# Altium schematic sheet FC11.SchDoc(FC11)
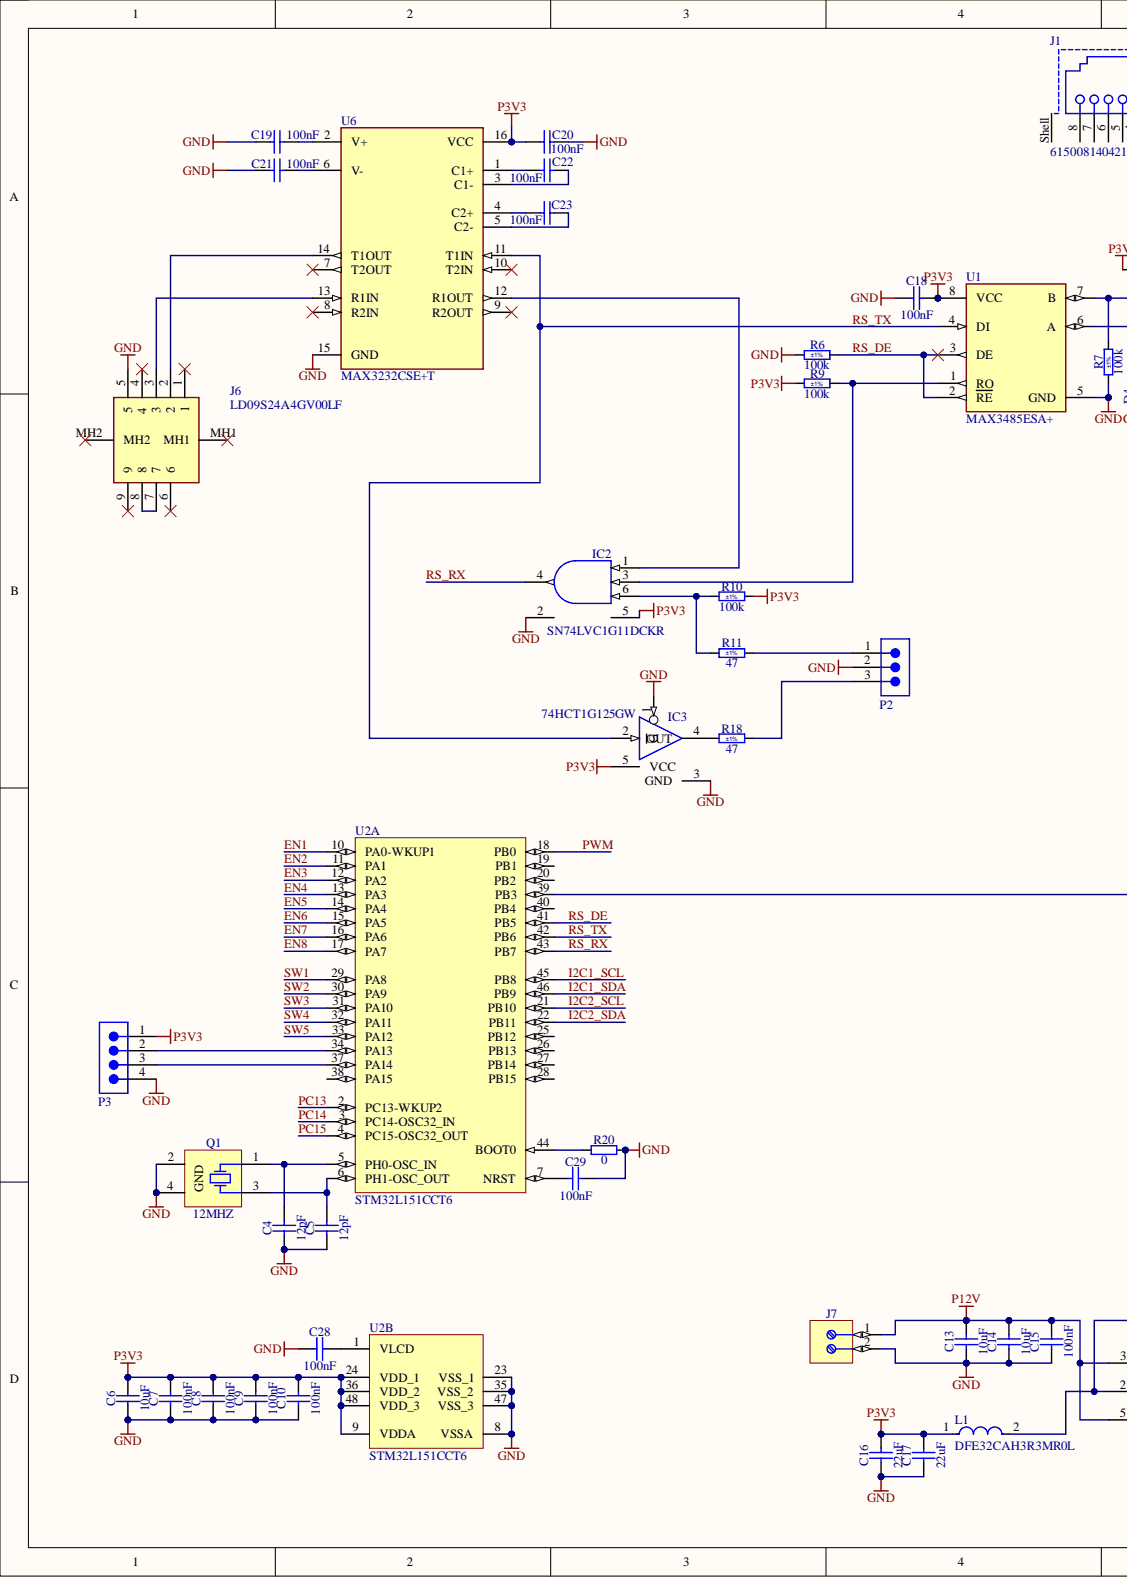
[diagram p0: FC11.SchDoc, left]
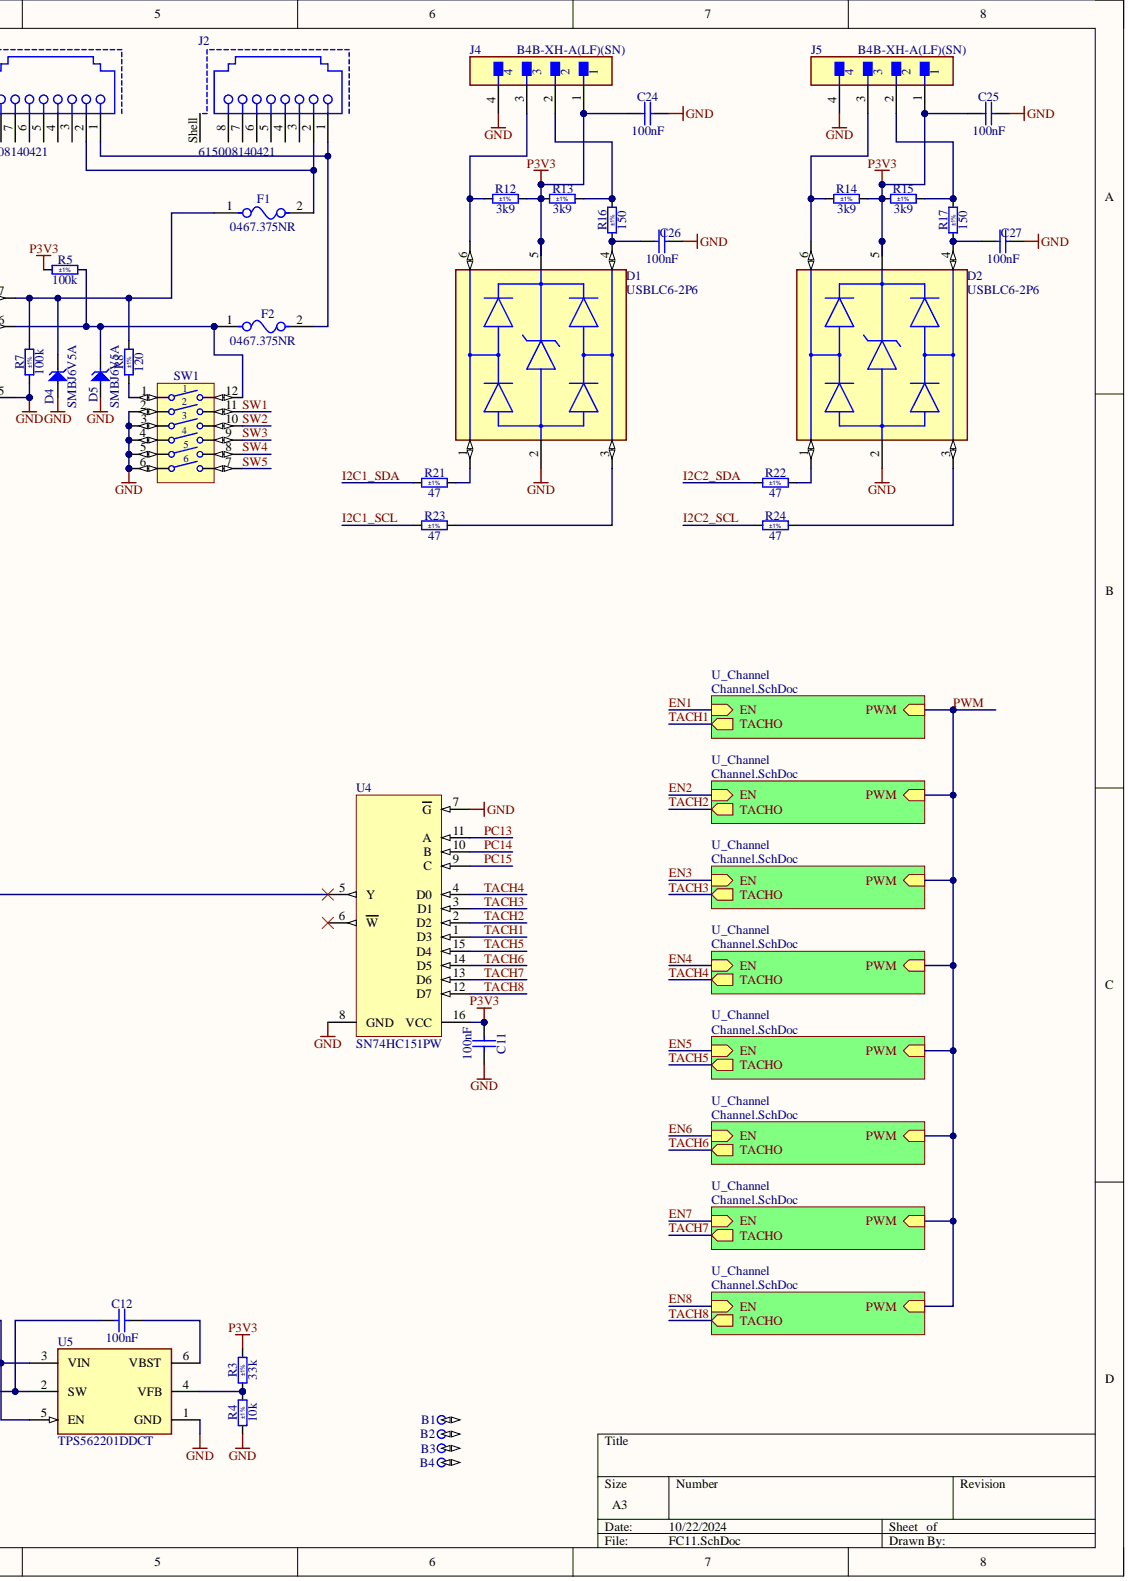
[diagram p1: FC11.SchDoc, right]

## Parts
B1  Plated Hole  [MTG300_600]  (value not drawn)  (no BOM)
B2  Plated Hole  [MTG300_600]  (value not drawn)  (no BOM)
B3  Plated Hole  [MTG300_600]  (value not drawn)  (no BOM)
B4  Plated Hole  [MTG300_600]  (value not drawn)  (no BOM)
C4  12pF  [CAPC1005X55N]
C5  12pF  [CAPC1005X55N]
C6  10uF  [CAPC2012X95N]
C7  100nF  [CAPC1005X55N]
C8  100nF  [CAPC1005X55N]
C9  100nF  [CAPC1005X55N]
C10  100nF  [CAPC1005X55N]
C11  100nF  [CAPC1005X55N]
C12  100nF  [CAPC1005X55N]
C13  10uF  [CAPC2012X95N]
C14  10uF  [CAPC2012X95N]
C15  100nF  [CAPC1005X55N]
C16  22uF  [CAPC2012X135N]
C17  22uF  [CAPC2012X135N]
C18  100nF  [CAPC1005X55N]
C19  100nF  [CAPC1005X55N]
C20  100nF  [CAPC1005X55N]
C21  100nF  [CAPC1005X55N]
C22  100nF  [CAPC1005X55N]
C23  100nF  [CAPC1005X55N]
C24  100nF  [CAPC1005X55N]
C25  100nF  [CAPC1005X55N]
C26  100nF  [CAPC1005X55N]
C27  100nF  [CAPC1005X55N]
C28  100nF  [CAPC1005X55N]
C29  100nF  [CAPC1005X55N]
D1  USBLC6-2P6
D2  USBLC6-2P6
D4  SMBJ6V5A  [DIOM5336X265N]
D5  SMBJ6V5A  [DIOM5336X265N]
F1  0467.375NR
F2  0467.375NR
IC2  SN74LVC1G11DCKR  [SOT65P210X110-6N]
IC3  74HCT1G125GW  [SOT65P210X110-5N]
J1  615008140421
J2  615008140421
J4  B4B-XH-A(LF)(SN)
J5  B4B-XH-A(LF)(SN)
J6  LD09S24A4GV00LF
J7  (no value)  [PHOENIX_1725656]
L1  DFE32CAH3R3MR0L
P2  61300311121  (value not drawn)
P3  61300411121  (value not drawn)
Q1  12MHZ  [XTAL_ABRACON_ABM3B]
R3  33k  Tolerance: ±1%  [RESC1005X40N]
R4  10k  Tolerance: ±1%  [RESC1005X40N]
R5  100k  Tolerance: ±1%  [RESC1005X40N]
R6  100k  Tolerance: ±1%  [RESC1005X40N]
R7  100k  Tolerance: ±1%  [RESC1005X40N]
R8  120  Tolerance: ±1%  [RESC1608X55N]
R9  100k  Tolerance: ±1%  [RESC1005X40N]
R10  100k  Tolerance: ±1%  [RESC1005X40N]
R11  47  Tolerance: ±1%  [RESC1005X40N]
R12  3k9  Tolerance: ±1%  [RESC1005X40N]
R13  3k9  Tolerance: ±1%  [RESC1005X40N]
R14  3k9  Tolerance: ±1%  [RESC1005X40N]
R15  3k9  Tolerance: ±1%  [RESC1005X40N]
R16  150  Tolerance: ±1%  [RESC1005X40N]
R17  150  Tolerance: ±1%  [RESC1005X40N]
R18  47  Tolerance: ±1%  [RESC1005X40N]
R20  0  [RESC1005X40N]
R21  47  Tolerance: ±1%  [RESC1005X40N]
R22  47  Tolerance: ±1%  [RESC1005X40N]
R23  47  Tolerance: ±1%  [RESC1005X40N]
R24  47  Tolerance: ±1%  [RESC1005X40N]
SW1  Switch'  [SW_OMRON_A6H-6102]  (value not drawn)
U1  MAX3485ESA+
U2  STM32L151CCT6  (parts A,B)
U4  SN74HC151PW
U5  TPS562201DDCT
U6  MAX3232CSE+T

## Nets
GND: C4.1 C5.1 C6.1 C7.1 C8.1 C9.1 C10.1 C11.1 C13.1 C14.1 C15.1 C16.1 C17.1 C18.1 C19.2 C20.1 C21.2 C24.2 C25.2 C26.2 C27.2 C28.1 C29.2 D1.2 D2.2 D4.2 D5.2 IC2.2 IC3.1(G) IC3.3(GND) J4.4 J5.4 J6.5 J7.2 P2.2 P3.4 Q1.2 Q1.4 R4.1 R6.1 R7.1 R20.2 SW1.2 SW1.3 SW1.4 SW1.5 SW1.6 U1.5(GND) U2.8(VSSA) U2.23(VSS_1) U2.35(VSS_2) U2.47(VSS_3) U4.7(G) U4.8(GND) U5.1(GND) U6.15(GND)
P3V3: C6.2 C7.2 C8.2 C9.2 C10.2 C11.2 C16.2 C17.2 C18.2 C20.2 C24.1 C25.1 D1.5 D2.5 IC2.5 IC3.5(VCC) J4.1 J5.1 L1.1 P3.1 R3.2 R5.1 R9.1 R10.2 R12.2 R13.1 R14.2 R15.1 U1.8(VCC) U2.9(VDDA) U2.24(VDD_1) U2.36(VDD_2) U2.48(VDD_3) U4.16(VCC) U6.16(VCC)
P12V: C13.2 C14.2 C15.2 J7.1 U5.3(VIN) U5.5(EN)
EN1: U2.10(PA0-WKUP1)
EN2: U2.11(PA1)
EN3: U2.12(PA2)
EN4: U2.13(PA3)
EN5: U2.14(PA4)
EN6: U2.15(PA5)
EN7: U2.16(PA6)
EN8: U2.17(PA7)
I2C1_SCL: R23.1 U2.45(PB8)
I2C1_SDA: R21.1 U2.46(PB9)
I2C2_SCL: R24.1 U2.21(PB10)
I2C2_SDA: R22.1 U2.22(PB11)
PC13: U2.2(PC13-WKUP2) U4.11(A)
PC14: U2.3(PC14-OSC32_IN) U4.10(B)
PC15: U2.4(PC15-OSC32_OUT) U4.9(C)
PWM: U2.18(PB0)
RS_DE: R6.2 U1.2(RE) U1.3(DE) U2.41(PB5)
RS_RX: IC2.4 U2.43(PB7)
RS_TX: IC3.2(OUT) U1.4(DI) U2.42(PB6) U6.11(T1IN)
SW1: SW1.11 U2.29(PA8)
SW2: SW1.10 U2.30(PA9)
SW3: SW1.9 U2.31(PA10)
SW4: SW1.8 U2.32(PA11)
SW5: SW1.7 U2.33(PA12)
TACH1: U4.1(D3)
TACH2: U4.2(D2)
TACH3: U4.3(D1)
TACH4: U4.4(D0)
TACH5: U4.15(D4)
TACH6: U4.14(D5)
TACH7: U4.13(D6)
TACH8: U4.12(D7)
NetB1_1: B1.1
NetB2_1: B2.1
NetB3_1: B3.1
NetB4_1: B4.1
NetC4_2: C4.2 Q1.1 U2.5(PH0-OSC_IN)
NetC5_2: C5.2 Q1.3 U2.6(PH1-OSC_OUT)
NetC12_1: C12.1 L1.2 U5.2(SW)
NetC12_2: C12.2 U5.6(VBST)
NetC19_1: C19.1 U6.2(V+)
NetC21_1: C21.1 U6.6(V-)
NetC22_1: C22.1 U6.3(C1-)
NetC22_2: C22.2 U6.1(C1+)
NetC23_1: C23.1 U6.5(C2-)
NetC23_2: C23.2 U6.4(C2+)
NetC26_1: C26.1 D1.4 R16.1
NetC27_1: C27.1 D2.4 R17.1
NetC28_2: C28.2 U2.1(VLCD)
NetC29_1: C29.1 U2.7(NRST)
NetD1_1: D1.1 R21.2
NetD1_3: D1.3 R23.2
NetD1_6: D1.6 J4.3 R12.1
NetD2_1: D2.1 R22.2
NetD2_3: D2.3 R24.2
NetD2_6: D2.6 J5.3 R14.1
NetD4_1: D4.1 F1.1 R7.2 R8.2 U1.7(B)
NetD5_1: D5.1 F2.1 R5.2 SW1.12 U1.6(A)
NetF1_2: F1.2 J1.2 J2.2
NetF2_2: F2.2 J1.1 J2.1
NetIC2_1: IC2.1 U6.12(R1OUT)
NetIC2_3: IC2.3 R9.2 U1.1(RO)
NetIC2_6: IC2.6 R10.1 R11.1
NetIC3_4: IC3.4 R18.1
NetJ1_3: J1.3
NetJ1_4: J1.4
NetJ1_5: J1.5
NetJ1_6: J1.6
NetJ1_7: J1.7
NetJ1_8: J1.8
NetJ1_Shell: J1.Shell
NetJ2_3: J2.3
NetJ2_4: J2.4
NetJ2_5: J2.5
NetJ2_6: J2.6
NetJ2_7: J2.7
NetJ2_8: J2.8
NetJ2_Shell: J2.Shell
NetJ4_2: J4.2 R13.2 R16.2
NetJ5_2: J5.2 R15.2 R17.2
NetJ6_1: J6.1
NetJ6_2: J6.2 U6.14(T1OUT)
NetJ6_3: J6.3 U6.13(R1IN)
NetJ6_4: J6.4
NetJ6_6: J6.6
NetJ6_7: J6.7 J6.8
NetJ6_9: J6.9
NetJ6_MH1: J6.MH1
NetJ6_MH2: J6.MH2
NetP2_1: P2.1 R11.2
NetP2_3: P2.3 R18.2
NetP3_2: P3.2 U2.34(PA13)
NetP3_3: P3.3 U2.37(PA14)
NetR3_1: R3.1 R4.2 U5.4(VFB)
NetR8_1: R8.1 SW1.1
NetR20_1: R20.1 U2.44(BOOT0)
NetU2_19: U2.19(PB1)
NetU2_20: U2.20(PB2)
NetU2_25: U2.25(PB12)
NetU2_26: U2.26(PB13)
NetU2_27: U2.27(PB14)
NetU2_28: U2.28(PB15)
NetU2_38: U2.38(PA15)
NetU2_39: U2.39(PB3) U4.5(Y)
NetU2_40: U2.40(PB4)
NetU4_6: U4.6(W)
NetU6_7: U6.7(T2OUT)
NetU6_8: U6.8(R2IN)
NetU6_9: U6.9(R2OUT)
NetU6_10: U6.10(T2IN)

## Library data of the parts
B1-B4 = PLATED_HOLE3.0_PAD6.0: Single Terminal Socket; Part Description: Plated Through Hole: Hole Dia.=3.0mm Pad Dia.=6.0mm; Database Table Name: Pads; Mounted: No; Component Type: Standard (No BOM); ComponentHeight: 0mm; CreateDate: 26.05.2008; Family: Plated Hole; HelpURL: Undefined; LatestRevisionDate: 26.05.2008; Library Name: Eletro-mechanical.DbLib; PackageDescription: Plated Through Hole: Hole Dia.=3.0mm Pad Dia.=6.0mm; Part Number: PLATED_HOLE3.0_PAD6.0; Pin Count: 1; Sense: No; SMD: No; Socket: No; Status: None; Author: CERN DEM JLC
C4 C5 = CC0402_12PF_50V_5%_C0G: Non-polarised capacitor; Manufacturer: GENERIC; Manufacturer1 Part Number: C0402C120J5GACTU; Part Description: SMD Multilayer Chip Ceramic Capacitor; Database Table Name: Capacitors SMD; TC: C0G/NP0; Tolerance: ±5%; Voltage: 50V; Case: 0402; Component Kind: Standard; Component Type: Standard; CreateDate: 04.10.2016; HelpURL: \\cern.ch\dfs\Applications\Altium\Datasheets\CC0402_C0G_KEMET_(Commercial Grade).pdf; LatestRevisionDate: 04.10.2016; Library Name: Capacitors.DbLib; Manufacturer1 ComponentHeight: 0.55mm; Manufacturer1 Example: KEMET; Manufacturer Part Number: CC0402_12PF_50V_5%_C0G; Mounted: Yes; Part Number: CC0402_12PF_50V_5%_C0G; Pin Count: 2; Sense: No; SMD: Yes; Socket: No; Status: Preferred; Value: 12pF; Author: CERN DEM JLC
C6 C13 C14 = CC0805_10UF_25V_10%_X5R: Non-polarised capacitor; Manufacturer: GENERIC; Manufacturer1 Part Number: GRM219R61E106KA12D; Part Description: SMD Multilayer Chip Ceramic Capacitor; Database Table Name: Capacitors SMD; TC: X5R; Tolerance: ±10%; Voltage: 25V; Case: 0805; Component Kind: Standard; Component Type: Standard; CreateDate: 25.10.2012; HelpURL: \\cern.ch\dfs\Applications\Altium\Datasheets\CC0805_X5R_MURATA_GRM.pdf; LatestRevisionDate: 06.09.2016; Library Name: Capacitors.DbLib; Manufacturer1 ComponentHeight: 0.95mm; Manufacturer1 Example: MURATA; Manufacturer Part Number: CC0805_10UF_25V_10%_X5R; Mounted: Yes; Part Number: CC0805_10UF_25V_10%_X5R; Pin Count: 2; Sense: No; SMD: Yes; Socket: No; Status: Preferred; Value: 10uF; Author: CERN DEM JLC
C7-C12 C15 C18-C29 = CC0402_100NF_16V_10%_X5R: Non-polarised capacitor; Manufacturer: GENERIC; Manufacturer1 Part Number: GRM155R61C104KA88D; Part Description: SMD Multilayer Chip Ceramic Capacitor; Database Table Name: Capacitors SMD; TC: X5R; Tolerance: ±10%; Voltage: 16V; Case: 0402; Component Kind: Standard; Component Type: Standard; CreateDate: 10.12.2013; HelpURL: \\cern.ch\dfs\Applications\Altium\Datasheets\CC0402_X5R_MURATA_GRM.pdf; LatestRevisionDate: 10.12.2013; Library Name: Capacitors.DbLib; Manufacturer1 ComponentHeight: 0.55mm; Manufacturer1 Example: MURATA; Manufacturer Part Number: CC0402_100NF_16V_10%_X5R; Mounted: Yes; Part Number: CC0402_100NF_16V_10%_X5R; Pin Count: 2; Sense: No; SMD: Yes; Socket: No; Status: None; Value: 100nF; Author: CERN DEM JLC
C16 C17 = CC0805_22UF_16V_10%_X5R: Non-polarised capacitor; Manufacturer: GENERIC; Manufacturer1 Part Number: C2012X5R1C226KT; Part Description: SMD Multilayer Chip Ceramic Capacitor; Database Table Name: Capacitors SMD; TC: X5R; Tolerance: ±10%; Voltage: 16V; Case: 0805; Component Kind: Standard; Component Type: Standard; CreateDate: 25.10.2012; HelpURL: \\cern.ch\dfs\Applications\Altium\Datasheets\CC0805_X5R_TDK_C.pdf; LatestRevisionDate: 25.10.2012; Library Name: Capacitors.DbLib; Manufacturer1 ComponentHeight: 1.35mm; Manufacturer1 Example: TDK; Manufacturer Part Number: CC0805_22UF_16V_10%_X5R; Mounted: Yes; Part Number: CC0805_22UF_16V_10%_X5R; Pin Count: 2; Sense: No; SMD: Yes; Socket: No; Status: Preferred; Value: 22uF; Author: CERN DEM JLC
D1 D2 = CMP-12225-000058-1: TVS DIODE 5.25V 17V SOT666; Breakdown Voltage: 6V; Capacitance: 3.5pF; Direction: Unidirectional; Element Configuration: Dual; ESD Protection: Yes; Leakage Current: 150nA; Number of Bidirectional Channels: 2; Number of Elements: 1; Operating Supply Voltage: 5V; Peak Pulse Current: 5A; Test Current: 1mA; Case/Package: SOT-666; Clamping Voltage: 17V; Component Kind: Standard; Contact Plating: Tin; Depth: 1.3mm; Height: 0.6mm; Lead Free: Lead Free; Length: 1.7mm; Library Name: Altium Content Vault; Max Breakdown Voltage: 6V; Max Operating Temperature: 125°C; Max Reverse Leakage Current: 150nA; Min Breakdown Voltage: 6V; Min Operating Temperature: -40°C; Mount: Surface Mount; Number of Channels: 2; Number of Pins: 6; Package Quantity: 1; Packaging: Tape and Reel; Polarity: Unidirectional; Power Line Protection: Yes; Radiation Hardening: No; REACH SVHC: No SVHC; Reverse Breakdown Voltage: 6V; Reverse Standoff Voltage: 5V; Termination: SMD/SMT; Width: 1.3mm; Working Voltage: 5V
D4 D5 = SMBJ6V5A: Transient Voltage Suppressor Diode (Uni-Directional); Manufacturer: FAIRCHILD SEMICONDUCTOR; Part Description: Uni-Directional Transient Voltage Suppressor Diode; Bonding: No; Database Table Name: Diodes; Power: 600W; PressFit: No; Voltage: 6.5V; Case: DO-214AA; Component Kind: Standard; Component Type: Standard; ComponentHeight: 2.65mm; CreateDate: 04.09.2017; Device: SMBJ6V5A; HelpURL: \\cern.ch\dfs\Applications\Altium\Datasheets\SMBJ.pdf; LatestRevisionDate: 04.09.2017; Library Name: ICs And Semiconductors.DbLib; Manufacturer Part Number: SMBJ6V5A; Mounted: Yes; PackageDescription: DO-214-AA/SMB Diode, Molded Body; 5.34mm L X 3.625mm W X 2.65mm H, IPC Medium Density; Part Number: SMBJ6V5A; Pin Count: 2; Sense: No; SMD: Yes; Socket: No; Author: CERN DEM MR
F1 F2 = CMP-08605-000028-1: FUSE BOARD MOUNT 375MA 32VAC/VDC; Manufacturer: Littelfuse; Fuse Blow Speed: Fast; Fuse Current: 0.375A; Fuse I2T: 0.0035A2Sec; Fuse Interrupt Ac Current: 50A; Max Fuse Ac Voltage: 32V; Automotive: No; Category: Fuse; Component Kind: Standard; ComponentLink2Description: Datasheet; ComponentLink2URL: https://www.littelfuse.com/~/media/electronics/datasheets/fuses/littelfuse_fuse_467_datasheet.pdf.pdf; Device Class L1: Circuit Protection; Device Class L2: Fuses; Device Class L3: unset; Digikey Description: FUSE BOARD MOUNT 375MA 32VAC/VDC; Fuse Interrupt Dc Current: 50A; Height: 0.432mm; Lead Free: Yes; Library Name: Altium Content Vault; Manufacturer Part Number: 0467.375NR; Max Fuse Dc Voltage: 32V; Mouser Description: Surface Mount Fuses 32V.375A VFA Slimline; Octopart: https://octopart.com/0467.375nr-littelfuse-565971; Package: 467; RoHS: TRUE; Temperature: -55°C to +90°C; Temperature Range High: +90°C; Temperature Range Low: -55°C; Voltage Rating: 32V
IC2 = SN74LVC1G11DCKR: Single 3-Input Positive-AND Gate; Manufacturer: TEXAS INSTRUMENTS; Bonding: No; Database Table Name: Standard Logic; PressFit: No; Case: SOT363; Component Kind: Standard; Component Type: Standard; ComponentHeight: 1.1mm; CreateDate: 21.07.2014; Device: SN74LVC1G11DCKR; HelpURL: \\cern.ch\dfs\Applications\Altium\Datasheets\SN74LVC1G11DCKR.pdf; LatestRevisionDate: 21.07.2014; Library Name: ICs And Semiconductors.DbLib; Manufacturer Part Number: SN74LVC1G11DCKR; Mounted: Yes; PackageDescription: SOT363, SC70-6, 0.65mm Pitch; 6 pin, 1.25mm W X 2.00mm L X 1.10mm H body, IPC Medium Density; Part Description: Single 3-Input Positive-AND Gate; Part Number: SN74LVC1G11DCKR; Pin Count: 6; Sense: No; SMD: Yes; Socket: No; Author: CERN DEM JLC
IC3 = 74HCT1G125GW: Single Bus Buffer Gate With 3-State Output; Manufacturer: NEXPERIA; Part Description: Bus Buffer/Line Driver 3-State; Bonding: No; Database Table Name: Standard Logic; PressFit: No; Case: SOT353; Component Kind: Standard; Component Type: Standard; ComponentHeight: 1.1mm; CreateDate: 06.08.2020; Device: 74HCT1G125GW; HelpURL: \\cern.ch\dfs\Applications\Altium\Datasheets\74HCT1G125GW.pdf; LatestRevisionDate: 06.08.2020; Library Name: ICs And Semiconductors.DbLib; Manufacturer Part Number: 74HCT1G125GW; Mounted: Yes; PackageDescription: SOT353, SC70-5, 0.65mm Pitch; 5 Pin, 1.25mm W X 2.00mm L X 1.10mm H body, IPC Medium Density; Part Number: 74HCT1G125GW; Pin Count: 5; Sense: No; SMD: Yes; Socket: No; Author: CERN DEM JMW
J1 J2 = CMP-1502-01733-2: NexarPartId: 18818182; Connector Type: RJ45, Socket; Contact Resistance: 20mΩ; Current Rating: 1.5A; Direction: User Selectable; Gender: Female; Insulation Resistance: 500MΩ; Number of Contacts: 8; Number of Ports: 1; Operating Supply Voltage: 120V; Shielding: Shielded; Color: Black; Component Kind: Standard; Contact Material: Copper; Contact Plating: Gold; Depth: 16.4mm; Flammability Rating: UL94 V-0; Height: 16.65mm; Housing Material: PBT; Insulation Material: PBT; Lead Free: Lead Free; Length: 16.65mm; Library Name: Altium Content Vault; Max Operating Temperature: 85°C; Min Operating Temperature: -40°C; Mount: Through Hole; Number of Positions: 8; Number of Rows: 1; Orientation: Vertical; REACH SVHC: Unknown; RoHS: Compliant; Termination: Through Hole; Voltage Rating: 120V; Voltage Rating (AC): 120V; Width: 19.5mm
J4 J5 = CMP-17439-000250-1: CONN HEADER VERT 4POS 2.5MM; Contact Resistance: 20mR; Current Rating: 3A; Gender: Male; Insulation Resistance: 1GR; Mated Stacking Heights: 9.8mm; Max Voltage Rating (AC): 250V; Number of Contacts: 4; Wire/Cable Gauge: 22AWG; Color: Natural; Component Kind: Standard; Connector Type: Header; Contact Finish - Mating: TIN; Contact Material: Brass; Contact Plating: Tin; Contact Shape: Square; Contact Type: Male Pin; Depth: 5.75mm; Fastening Type: Detent; Flammability Rating: UL94 V-0; Housing Color: White; Housing Material: Polyamide; Insulation Color: Natural; Insulation Material: Nylon; Lead Free: Lead Free; Lead Pitch: 2.5mm; Length: 12.4mm; Library Name: Altium Content Vault; Material: Polyamide; Max Current Rating: 3A; Max Operating Temperature: 85°C; Max Voltage Rating (DC): 250V; Min Operating Temperature: -25°C; Mount: Through Hole; Number of Positions: 4; Number of Rows: 1; Orientation: Straight; Package Quantity: 500; Packaging: Bulk; Pitch: 2.5mm; REACH SVHC: No SVHC; Series: XH; Termination: Solder; Voltage Rating: 250V; Voltage Rating (AC): 250V
J6 = LD09S24A4GV00LF: D-Subminiature Economy Range, Input Output Connectors, Signal 9 way Socket Straight, Solder, 50 cycles, Harpoons + Female Screw UNC 4 - 40 + Elec cont., 1.6 mm PCB thickness; Manufacturer_Name: Amphenol Communications Solutions; Geometry.Height: 12.39mm; Arrow Part Number: LD09S24A4GV00LF; Arrow Price/Stock: https://www.arrow.com/en/products/ld09s24a4gv00lf/amphenol-fci?region=europe; Component Kind: Standard; Datasheet Link: https://cdn.amphenol-cs.com/media/wysiwyg/files/drawing/10130912.pdf; Library Name: LD09S24A4GV00LF.SchLib; Manufacturer_Part_Number: LD09S24A4GV00LF
J7 = PHOENIX_1725656: Terminal Block, 2 Positions, Screw Connection; Manufacturer: PHOENIX CONTACT; Manufacturer Part Number: 1725656 (MPT 0,5/2-2,54); Part Description: 6A, 63V, Terminal Block, Pitch 2.54mm, 2 Positions, Screw Connection; PressFit: No; Component Kind: Standard; Component Type: Standard; ComponentHeight: 8.5mm; CreateDate: 07.11.2008; Database Table Name: PHOENIX; HelpURL: \\cern.ch\dfs\Applications\Altium\Datasheets\PHOENIX_1725656.pdf; LatestRevisionDate: 26.04.2010; Library Name: Connectors.DbLib; Mounted: Yes; Part Number: PHOENIX_1725656; Pin Count: 2; Sense: No; SMD: No; Socket: No; Status: None; Author: CERN DEM JMW
L1 = CMP-00260-130551521-1: DFE32CAH3R3MR0L Inductor Chip Shielded Wirewound 3.3uH 20% 1MHz Metal Alloy 2.3A 1210 Emboss T/R; Inductance: 3.3µH; Saturation Current: 4.6A; Series Resistance: 67mΩ; Case/Package: 1210; Component Kind: Standard; Composition: Wirewound; Height: 2mm; Library Name: Altium Content Vault; Lifecycle Status: Production; Max Operating Temperature: 150°C; Min Operating Temperature: -55°C; NexarPartId: 130551521; RMS Current (Irms): 2.3A; Test Frequency: 1MHz; Tolerance: 20%
P2 = 61300311121: WR-PHD Pin Header, THT, Vertical, pitch 2.54mm, 1 Row, 3P; Manufacturer: Wurth Elektronik; Contact Resistance: 20mΩ; Rated Current: 3A; Withstanding Voltage: 500V; Working Voltage: 250V; Category: Board-to-Board Connectors; Component Kind: Standard; ComponentLink1Description: Website Link; ComponentLink1URL: https://www.we-online.com/redexpert/article/61300311121?ad; ComponentLink2Description: Datasheet Link; ComponentLink2URL: https://www.we-online.com/redexpert/spec/61300311121?ad; Gender: Pin Header; Library Name: Altium_WR-PHD (rev22b) (1).IntLib; Manufacturer Part Number: 61300311121; Match Code: WR-PHD; Mount: Through Hole; Number of Pins: 3; Number of Rows: 1; Operating Temperature Max: 105°C; Operating Temperature Min: -40°C; Orientation: Vertical; Packaging: Bag; Pitch: 2.54mm
P3 = 61300411121: WR-PHD Pin Header, THT, Vertical, pitch 2.54mm, 1 Row, 4P; Manufacturer: Wurth Elektronik; Contact Resistance: 20mΩ; Rated Current: 3A; Withstanding Voltage: 500V; Working Voltage: 250V; Category: Board-to-Board Connectors; Component Kind: Standard; ComponentLink1Description: Website Link; ComponentLink1URL: https://www.we-online.com/redexpert/article/61300411121?ad; ComponentLink2Description: Datasheet Link; ComponentLink2URL: https://www.we-online.com/redexpert/spec/61300411121?ad; Gender: Pin Header; Library Name: Altium_WR-PHD (rev22b) (1).IntLib; Manufacturer Part Number: 61300411121; Match Code: WR-PHD; Mount: Through Hole; Number of Pins: 4; Number of Rows: 1; Operating Temperature Max: 105°C; Operating Temperature Min: -40°C; Orientation: Vertical; Packaging: Bag; Pitch: 2.54mm
Q1 = XTAL_12MHZ_ABRACON_ABM3B-12.000MHZ-B2-T: Crystal 4 pins 1=XTAL Control 2=GND3=XTAL 4=GND; Part Description: Tolerance:± 20ppm, Stability:± 50ppm, -20°C to +70°C, 18pF, Ceramic SMD Crystal, ABM3B Series; Bonding: No; Database Table Name: Crystals & Oscillators; PressFit: No; Component Kind: Standard; Component Type: Standard; ComponentHeight: 1.1mm; CreateDate: 06.03.2012; HelpURL: \\cern.ch\dfs\Applications\Altium\Datasheets\XTAL_ABRACON_ABM3B.pdf; LatestRevisionDate: 06.03.2012; Library Name: ICs And Semiconductors.DbLib; Manufacturer: ABRACON; Manufacturer Part Number: ABM3B-12.000MHZ-B2-T; Mounted: Yes; PackageDescription: ABM3B Serie, SMD Crystal, Body 5x3.2mm; Part Number: XTAL_12MHZ_ABRACON_ABM3B-12.000MHZ-B2-T; Pin Count: 2; Sense: No; SMD: Yes; Socket: No; Value: 12MHZ; Author: CERN DEM JLC
R3 = R0402_33K_1%_0.0625W_100PPM: Resistor - 1%; Manufacturer: GENERIC; Manufacturer1 Part Number: 232270673303L; Part Description: General Purpose Thick Film Chip Resistor; Database Table Name: Resistors SMD; PressFit: No; TC: ±100ppm/°C; Case: 0402; Component Kind: Standard; Component Type: Standard; CreateDate: 03.12.2007; HelpURL: \\cern.ch\dfs\Applications\Altium\Datasheets\R0402_Phycomp_RC0402.pdf; LatestRevisionDate: 17.10.2012; Library Name: Resistors.DbLib; Manufacturer1 ComponentHeight: 0.4mm; Manufacturer1 Example: YAGEO PHYCOMP; Manufacturer Part Number: R0402_33K_1%_0.0625W_100PPM; Mounted: Yes; Part Number: R0402_33K_1%_0.0625W_100PPM; Pin Count: 2; Power: 0.0625W; Sense: No; SMD: Yes; Socket: No; Status: Not Recommended; Value: 33k; Author: CERN DEM JLC
R4 = R0402_10K_1%_0.0625W_100PPM: Resistor - 1%; Manufacturer: GENERIC; Manufacturer1 Part Number: 232270671003L; Part Description: General Purpose Thick Film Chip Resistor; Database Table Name: Resistors SMD; PressFit: No; TC: ±100ppm/°C; Case: 0402; Component Kind: Standard; Component Type: Standard; CreateDate: 03.12.2007; HelpURL: \\cern.ch\dfs\Applications\Altium\Datasheets\R0402_Phycomp_RC0402.pdf; LatestRevisionDate: 17.10.2012; Library Name: Resistors.DbLib; Manufacturer1 ComponentHeight: 0.4mm; Manufacturer1 Example: YAGEO PHYCOMP; Manufacturer Part Number: R0402_10K_1%_0.0625W_100PPM; Mounted: Yes; Part Number: R0402_10K_1%_0.0625W_100PPM; Pin Count: 2; Power: 0.0625W; Sense: No; SMD: Yes; Socket: No; Status: Not Recommended; Value: 10k; Author: CERN DEM JLC
R5-R7 R9 R10 = R0402_100K_1%_0.0625W_100PPM: Resistor - 1%; Manufacturer: GENERIC; Manufacturer1 Part Number: 232270671004L; Part Description: General Purpose Thick Film Chip Resistor; Database Table Name: Resistors SMD; PressFit: No; TC: ±100ppm/°C; Case: 0402; Component Kind: Standard; Component Type: Standard; CreateDate: 03.12.2007; HelpURL: \\cern.ch\dfs\Applications\Altium\Datasheets\R0402_Phycomp_RC0402.pdf; LatestRevisionDate: 17.10.2012; Library Name: Resistors.DbLib; Manufacturer1 ComponentHeight: 0.4mm; Manufacturer1 Example: YAGEO PHYCOMP; Manufacturer Part Number: R0402_100K_1%_0.0625W_100PPM; Mounted: Yes; Part Number: R0402_100K_1%_0.0625W_100PPM; Pin Count: 2; Power: 0.0625W; Sense: No; SMD: Yes; Socket: No; Status: Not Recommended; Value: 100k; Author: CERN DEM JLC
R8 = R0603_120R_1%_0.1W_100PPM: Resistor - 1%; Manufacturer: GENERIC; Manufacturer1 Part Number: NRC06F1200TRF; Part Description: General Purpose Thick Film Chip Resistor; Database Table Name: Resistors SMD; Power: 0.1W; PressFit: No; TC: ±100ppm/°C; Case: 0603; Component Kind: Standard; Component Type: Standard; CreateDate: 03.12.2007; HelpURL: \\cern.ch\dfs\Applications\Altium\Datasheets\R0603_NIC_NRC.pdf; LatestRevisionDate: 13.03.2008; Library Name: Resistors.DbLib; Manufacturer1 ComponentHeight: 0.55mm; Manufacturer1 Example: NIC COMPONENT; Manufacturer Part Number: R0603_120R_1%_0.1W_100PPM; Mounted: Yes; Part Number: R0603_120R_1%_0.1W_100PPM; Pin Count: 2; Sense: No; SMD: Yes; Socket: No; Status: Preferred; Value: 120; Author: CERN DEM JLC
R11 R18 R21-R24 = R0402_47R_1%_0.0625W_100PPM: Resistor - 1%; Manufacturer: GENERIC; Manufacturer1 Part Number: 232270674709L; Part Description: General Purpose Thick Film Chip Resistor; Database Table Name: Resistors SMD; PressFit: No; TC: ±100ppm/°C; Case: 0402; Component Kind: Standard; Component Type: Standard; CreateDate: 03.12.2007; HelpURL: \\cern.ch\dfs\Applications\Altium\Datasheets\R0402_Phycomp_RC0402.pdf; LatestRevisionDate: 17.10.2012; Library Name: Resistors.DbLib; Manufacturer1 ComponentHeight: 0.4mm; Manufacturer1 Example: YAGEO PHYCOMP; Manufacturer Part Number: R0402_47R_1%_0.0625W_100PPM; Mounted: Yes; Part Number: R0402_47R_1%_0.0625W_100PPM; Pin Count: 2; Power: 0.0625W; Sense: No; SMD: Yes; Socket: No; Status: Not Recommended; Value: 47; Author: CERN DEM JLC
R12-R15 = R0402_3K9_1%_0.0625W_100PPM: Resistor - 1%; Manufacturer: GENERIC; Manufacturer1 Part Number: 232270673902L; Part Description: General Purpose Thick Film Chip Resistor; Database Table Name: Resistors SMD; PressFit: No; TC: ±100ppm/°C; Case: 0402; Component Kind: Standard; Component Type: Standard; CreateDate: 03.12.2007; HelpURL: \\cern.ch\dfs\Applications\Altium\Datasheets\R0402_Phycomp_RC0402.pdf; LatestRevisionDate: 17.10.2012; Library Name: Resistors.DbLib; Manufacturer1 ComponentHeight: 0.4mm; Manufacturer1 Example: YAGEO PHYCOMP; Manufacturer Part Number: R0402_3K9_1%_0.0625W_100PPM; Mounted: Yes; Part Number: R0402_3K9_1%_0.0625W_100PPM; Pin Count: 2; Power: 0.0625W; Sense: No; SMD: Yes; Socket: No; Status: Not Recommended; Value: 3k9; Author: CERN DEM JLC
R16 R17 = R0402_150R_1%_0.0625W_100PPM: Resistor - 1%; Manufacturer: GENERIC; Manufacturer1 Part Number: 232270671501L; Part Description: General Purpose Thick Film Chip Resistor; Database Table Name: Resistors SMD; PressFit: No; TC: ±100ppm/°C; Case: 0402; Component Kind: Standard; Component Type: Standard; CreateDate: 03.12.2007; HelpURL: \\cern.ch\dfs\Applications\Altium\Datasheets\R0402_Phycomp_RC0402.pdf; LatestRevisionDate: 17.10.2012; Library Name: Resistors.DbLib; Manufacturer1 ComponentHeight: 0.4mm; Manufacturer1 Example: YAGEO PHYCOMP; Manufacturer Part Number: R0402_150R_1%_0.0625W_100PPM; Mounted: Yes; Part Number: R0402_150R_1%_0.0625W_100PPM; Pin Count: 2; Power: 0.0625W; Sense: No; SMD: Yes; Socket: No; Status: Not Recommended; Value: 150; Author: CERN DEM JLC
R20 = R0402_0R_JUMPER: Resistor; Manufacturer: GENERIC; Manufacturer1 Part Number: 232270591001L; Part Description: 1A (0.05R Max DC Resistance) Zero Ohm Jumper; Database Table Name: Resistors SMD; PressFit: No; Case: 0402; Component Kind: Standard; Component Type: Standard; CreateDate: 03.12.2007; HelpURL: \\cern.ch\dfs\Applications\Altium\Datasheets\R0402_Phycomp_RC0402.pdf; LatestRevisionDate: 17.10.2012; Library Name: Resistors.DbLib; Manufacturer1 ComponentHeight: 0.4mm; Manufacturer1 Example: YAGEO PHYCOMP; Manufacturer Part Number: R0402_0R_JUMPER; Mounted: Yes; Part Number: R0402_0R_JUMPER; Pin Count: 2; Sense: No; SMD: Yes; Socket: No; Status: Not Recommended; Value: 0; Author: CERN DEM JLC
SW1 = SW_OMRON_A6H-6102: 6 Ways SPST DIP Switch; Manufacturer: OMRON; Part Description: 6 Ways Ultra-low Profile, Half-pitch, SPST DIP Switch With Seal Tape, Rating 25mA 24VDC; Database Table Name: Switches; PressFit: No; Component Kind: Standard; Component Type: Standard; ComponentHeight: 1.45mm; CreateDate: 01.02.2018; Family: Switch; HelpURL: \\cern.ch\dfs\Applications\Altium\Datasheets\SW_OMRON_A6H-6102.pdf; LatestRevisionDate: 01.02.2018; Library Name: Eletro-mechanical.DbLib; Manufacturer Part Number: A6H-6102; Mounted: Yes; PackageDescription: Pitch 1.27mm, Body 8.85 x 4.5mm, 6 Ways SPST Surface-Mounting DIP Switch, OMRON A6H; Part Number: SW_OMRON_A6H-6102; Pin Count: 12; Sense: No; SMD: Yes; Socket: No; Status: None; Author: CERN DEM JLC
U1 = CMP-05679-000020-1: IC TXRX RS485/422 10MBPS 8SOIC; Manufacturer: Maxim Integrated; Data Rate: 10Mbits/s; Interface: RS-485,RS-422; Max Junction Temp: +160°C; Max Supply Voltage: 3.6V; Min Supply Voltage: 3V; Nominal Supply Current: 0.95-1.1mA; Number Of Receivers: 1; Simplex Duplex: Half Duplex; Standoff Height: 0.1mm; Automotive: No; Category: IC; Component Kind: Standard; ComponentLink2Description: Datasheet; ComponentLink2URL: https://datasheets.maximintegrated.com/en/ds/MAX3483-MAX3491.pdf; Device Class L1: Integrated Circuits (ICs); Device Class L2: Interface ICs; Device Class L3: RS-232 / RS-422 / RS-485 Interface ICs; Digikey Description: IC TXRX RS485/422 10MBPS 8SOIC; Footprint Url: https://pdfserv.maximintegrated.com/land_patterns/90-0096.PDF; Height: 1.75mm; Lead Free: Yes; Library Name: Altium Content Vault; Manufacturer Part Number: MAX3485ESA+; Mouser Description: 3.3V Powered, 10Mbps and Slew-Rate Limited, True RS-485/RS-422 Transceivers; Number Of Transmitters: 1; Octopart: https://octopart.com/max3485esa%2B-maxim+integrated-39731860; Package: S8-5; RoHS: TRUE; Temperature: -40°C to +85°C; Temperature Range High: +85°C; Temperature Range Low: -40°C
U2 = CMP-0236-00052-2: ARM Cortex-M3 32-bit MCU, 256 KB Flash, 32 KB Internal RAM, 37 I/Os, 48-pin LQFP, -40 to 85 degC, Tray; D/A Converter: 2x12-bit (2 Channel); Data E2PROM nom (B): 8192; FLASH Size (Prog) (kB): 256; I/Os (High Current): 37; Internal RAM Size (kB): 32; Supply Voltage(Vcc) min (V): 1.65; Component Kind: Standard; ComponentLink1Description: Manufacturer URL; ComponentLink1URL: http://www.st.com/web/en/home.html; ComponentLink2Description: Datasheet; ComponentLink2URL: http://www.st.com/st-web-ui/static/active/en/resource/technical/document/datasheet/DM00048356.pdf; ComponentLink3Description: Package Specification; ComponentLink3URL: http://www.st.com/st-web-ui/static/active/en/resource/technical/document/datasheet/DM00058181.pdf; Core: ARM Cortex-M3; DatasheetVersion: Rev. 3; Library Name: Altium Content Vault; Mounting Technology: Surface Mount; Operating Frequency(F) (Processor speed) (MHz): 32; PackageDescription: 48-Pin Low Profile Quad Flat Package, 7 x 7 mm Body, 0.5 mm Pitch; PackageReference: LQFP48; PackageVersion: Rev. 6, 06/2013; Packing: Tray; PartNumber: STM32L151CCT6
U4 = CMP-1633-00864-2: 8-Line To 1-Line Data Selectors/Multiplexers, PW0016A, TUBE; Manufacturer: Texas Instruments; Bits(#): 8; Channels(#): 1; Configuration: 8：1; F @ Nom Voltage(Max)(Mhz): 28; Function: Encoder/Multiplexer; ICC @ Nom Voltage(Max)(mA): 0.08; Input Type: LVTTL/CMOS; Output Drive (IOL/IOH)(Max)(mA): 7.8/-7.8; Output Type: CMOS; Rating: Catalog; Schmitt Trigger: No; Technology Family: HC; tpd @ Nom Voltage(Max)(ns): 63; VCC(Max)(V): 6; VCC(Min)(V): 2; Voltage(Nom)(V): 3.3,5; Code_JEDEC: MO-153; Component Kind: Standard; DatasheetVersion: SCLS110E; Generic Part Number: SN74HC151; Library Name: Altium Content Vault; Operating Temperature Range(C): -40 to 85; PackageReference: PW0016A; Type: Standard
U5 = CMP-04918-000010-1: IC REG BUCK ADJ 2A TSOT23-6; Manufacturer: Texas Instruments; Max Duty Cycle: 80%; Max Junction Temp: +125°C; Max Supply Voltage: 17V; Min Supply Voltage: 4.5V; Nominal Supply Current: 380uA; Number Of Outputs: 1; Output Type: AdjustableProgrammable; Output Voltage: 0.76-7V; Standoff Height: 0mm; Switching Frequency: 580kHz; Automotive: No; Category: IC; Component Kind: Standard; ComponentLink2Description: Datasheet; ComponentLink2URL: http://www.ti.com/lit/ds/symlink/tps562201.pdf; Device Class L1: Integrated Circuits (ICs); Device Class L2: Power Management ICs; Device Class L3: Voltage Regulators - Switching; Digikey Description: IC REG BUCK ADJ 2A TSOT23-6; Height: 1.1mm; Lead Free: Yes; Library Name: Altium Content Vault; Manufacturer Part Number: TPS562201DDCT; Mouser Description: Conv DC-DC 4.5V to 17V Synchronous Step Down Single-Out 0.76V to 7V 2A 6-Pin TSOT-23 T/R; Octopart: https://octopart.com/tps562201ddct-texas+instruments-68059584; Output Current: 2A; Package: DDC0006A; RoHS: TRUE; Switching Topology: Buck; Temperature: -40°C to +125°C; Temperature Range High: +125°C; Temperature Range Low: -40°C
U6 = CMP-2000-06662-2: IC TXRX RS-232 LP 16SOIC; Manufacturer: Maxim Integrated; Data Rate: 235kbits/s; Max Supply Voltage: 5.5V; Min Supply Voltage: 3V; Nominal Supply Current: 0.3mA; Number Of Receivers: 2; Simplex Duplex: Full Duplex; Standoff Height: 0.1mm; Automotive: No; Category: IC; Component Kind: Standard; ComponentLink2Description: Datasheet; ComponentLink2URL: https://www.maximintegrated.com/en/ds/MAX3222-MAX3241.pdf; Device Class L1: Integrated Circuits (ICs); Device Class L2: Interface ICs; Device Class L3: RS-232 / RS-422 / RS-485 Interface ICs; Digikey Description: IC TXRX RS-232 LP 16SOIC; Footprint Url: https://pdfserv.maximintegrated.com/land_patterns/90-0097.PDF; Height: 1.75mm; Interface: RS-232; Ipc Land Pattern Name: SOIC127P600X155-16; Lead Free: Yes; Library Name: Altium Content Vault; Manufacturer Part Number: MAX3232CSE+T; Mouser Description: RS-232 Interface IC 3-5.5V 235kbps Transceiver; Number Of Transmitters: 2; Octopart: https://octopart.com/max3232cse%2Bt-maxim+integrated-39731899; Package: S16-1; RoHS: TRUE; Temperature: 0°C to +70°C; Temperature Range High: +70°C; Temperature Range Low: 0°C
